# Altium schematic sheet actuator0.SchDoc
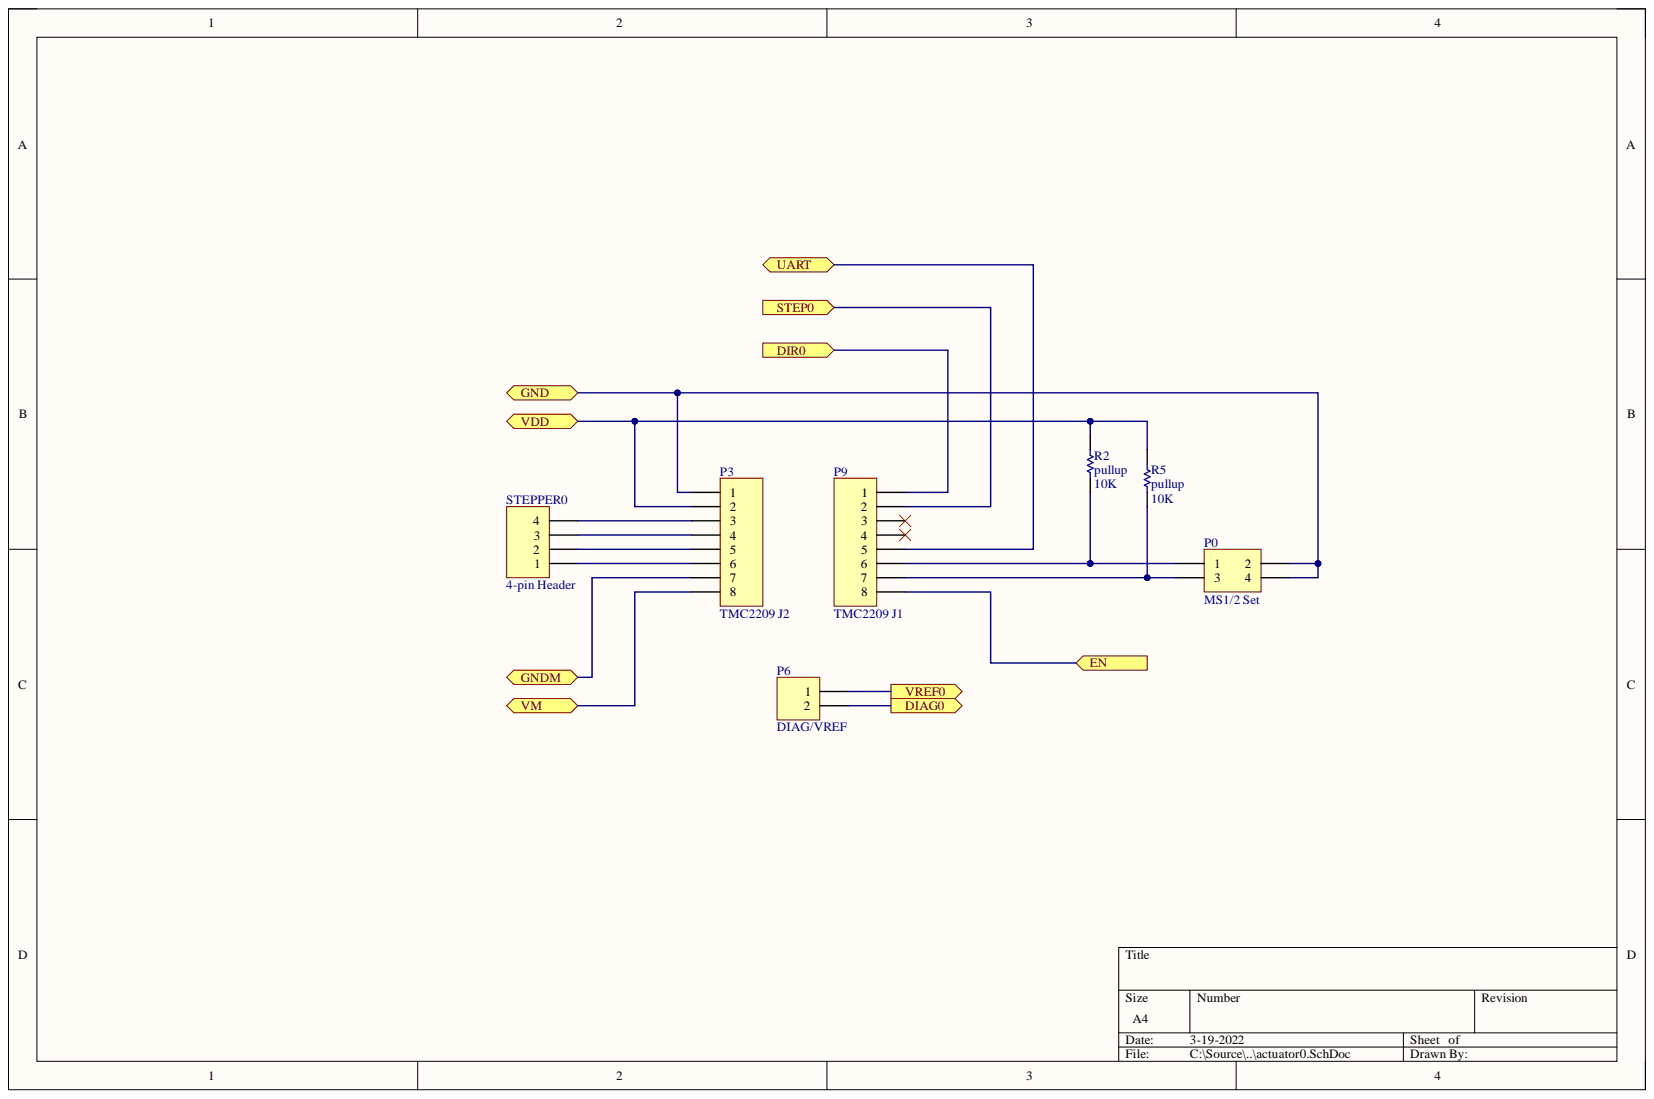
[diagram p0: actuator0.SchDoc]

## Parts
P0  MS1/2 Set  [HDR2X2]
P3  TMC2209 J2  [HDR1X8]
P6  DIAG/VREF  [HDR1X2]
P9  TMC2209 J1  [HDR1X8]
R2  pullup  value 10K  [AXIAL-0.3]
R5  pullup  value 10K  [AXIAL-0.3]
STEPPER0  4-pin Header  [HDR1X4]

## Ports
DIAG0, DIR0, EN, GND, GNDM, STEP0, UART, VDD, VM, VREF0

## Nets
DIAG0: P6.2 ; port DIAG0
DIR0: P9.1 ; port DIR0
EN: P9.8 ; port EN
GND: P0.2 P0.4 P3.1 ; port GND
GNDM: P3.7 ; port GNDM
STEP0: P9.2 ; port STEP0
UART: P9.5 ; port UART
VDD: P3.2 R2.2 R5.2 ; port VDD
VM: P3.8 ; port VM
VREF0: P6.1 ; port VREF0
NetP0_1: P0.1 P9.6 R2.1
NetP0_3: P0.3 P9.7 R5.1
NetP3_3: P3.3 STEPPER0.4
NetP3_4: P3.4 STEPPER0.3
NetP3_5: P3.5 STEPPER0.2
NetP3_6: P3.6 STEPPER0.1

Not connected: P9 3 4

## Library data of the parts
P0 = Header 2X2: Header, 2-Pin, Dual row; Component Kind: Standard; LatestRevisionDate: 17-Jul-2002; LatestRevisionNote: Re-released for DXP Platform.; Library Name: Miscellaneous Connectors.IntLib; Signal Integrity: Connector; Publisher: Altium Limited
P3 P9 = Header 8: Header, 8-Pin; Component Kind: Standard; LatestRevisionDate: 17-Jul-2002; LatestRevisionNote: Re-released for DXP Platform.; Library Name: miscellaneous_connectors.IntLIb; Signal Integrity: Connector; Publisher: Altium Limited
P6 = Header 2: Header, 2-Pin; Component Kind: Standard; LatestRevisionDate: 17-Jul-2002; LatestRevisionNote: Re-released for DXP Platform.; Library Name: miscellaneous_connectors.IntLIb; Signal Integrity: Connector; Publisher: Altium Limited
R2 R5 = Res1: Resistor; Component Kind: Standard; LatestRevisionDate: 17-Jul-2002; LatestRevisionNote: Re-released for DXP Platform.; Library Name: Miscellaneous Devices.IntLib; PackageDescription: Axial Device, Thru-Hole; 2 Leads; 0.3 in Pin Spacing; PackageReference: AXIAL-0.3; Published: 8-Jun-2000; Signal Integrity: Res; Simulation: RESISTOR; Publisher: Altium Limited
STEPPER0 = Header 4: Header, 4-Pin; Component Kind: Standard; LatestRevisionDate: 17-Jul-2002; LatestRevisionNote: Re-released for DXP Platform.; Library Name: Miscellaneous Connectors.IntLib; Signal Integrity: Connector; Publisher: Altium Limited
